# Altium schematic sheet Circuit_Schematic.SchDoc
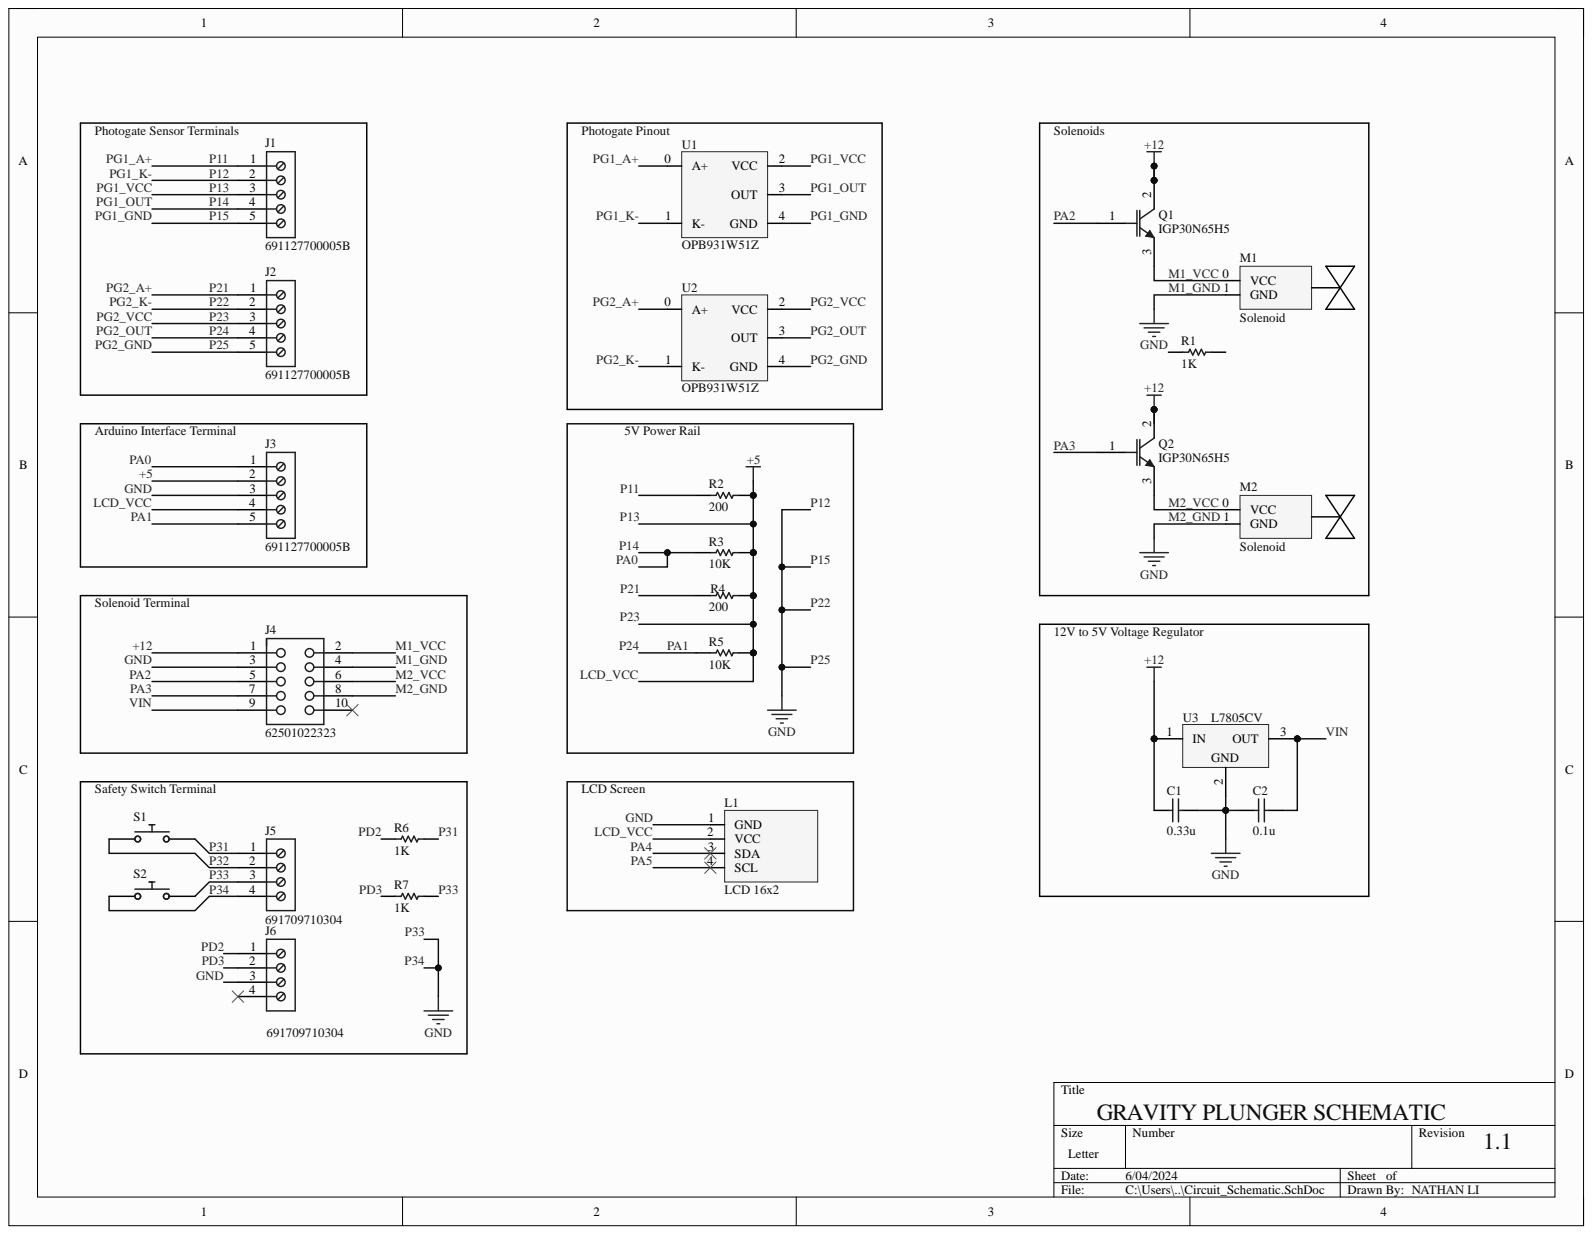
[diagram p0: Circuit_Schematic.SchDoc]

## Parts
C1  value 0.33u  (hidden comment: Capacitor)
C2  value 0.1u  (hidden comment: Capacitor)
J1  691127700005B  [691127700005B]
J2  691127700005B  [691127700005B]
J3  691127700005B  [691127700005B]
J4  62501022323  [62501022323]
J5  691709710304  [691709710304]
J6  691709710304  [691709710304]
L1  LCD 16x2
M1  Solenoid
M2  Solenoid
Q1  IGP30N65H5  [INF-PG-TO220-3-1_A_V]
Q2  IGP30N65H5  [INF-PG-TO220-3-1_A_V]
R1  value 1K  (hidden comment: Resistor)
R2  value 200  (hidden comment: Resistor)
R3  value 10K  (hidden comment: Resistor)
R4  value 200  (hidden comment: Resistor)
R5  value 10K  (hidden comment: Resistor)
R6  value 1K  (hidden comment: Resistor)
R7  value 1K  (hidden comment: Resistor)
S1  Push Button Normally Opened  (value not drawn)
S2  Push Button Normally Opened  (value not drawn)
U1  OPB931W51Z
U2  OPB931W51Z
U3  L7805CV  [TO220]

## Nets
+5: J1.3 J2.3 J3.2 J3.4 L1.2(VCC) R2.2 R3.2 R4.2 R5.2 U1.2(VCC) U2.2(VCC)
+12: C1.1 J4.1 Q1.2 Q2.2 U3.1
GND: C1.2 C2.1 J1.2 J1.5 J2.2 J2.5 J3.3 J4.3 J4.4 J4.8 J5.3 J5.4 J6.3 L1.1(GND) M1.1 M2.1 R7.2 S2.1 S2.2 U1.1(K-) U1.4(GND) U2.1(K-) U2.4(GND) U3.2
M1_VCC: J4.2 M1.0 Q1.3
M2_VCC: J4.6 M2.0 Q2.3
P11: J1.1 R2.1 U1.0(A+)
P14: J1.4 J3.1 R3.1 U1.3(OUT)
P21: J2.1 R4.1 U2.0(A+)
P24: J2.4 J3.5 R5.1 U2.3(OUT)
P31: J5.1 R6.2 S1.2
P32: J5.2 S1.1
PA2: J4.5 Q1.1
PA3: J4.7 Q2.1
PA4: L1.3(SDA)
PA5: L1.4(SCL)
PD2: J6.1 R6.1
PD3: J6.2 R7.1
VIN: C2.2 J4.9 U3.3

Not connected: J4 10; J6 4; R1 1 2

## Library data of the parts
C1 C2 = Capacitor: SimulationGenericComponent: True; Component Kind: Standard; Description: Capacitor; Library Name: Simulation Generic Components.IntLib; Simulation: C_Capacitor_Default
J1-J3 = CMP-1502-03273-2: NexarPartId: 27602165; Connector Type: Terminal Block; Current: 20A; Number of Contacts: 5; Number of Levels: 1; Pitch: 5.0038mm; Plating: Steel; Stripping Length: 9mm; Voltage: 300V; Wire Gauge (Max): 30AWG; Wire Gauge (Min): 12AWG; Color: Green; Component Kind: Standard; Contact Material: Brass; Contact Plating: Tin; Current Rating: 20A; Height: 19.5mm; Housing Material: Nylon; Lead Pitch: 5mm; Length: 18.6mm; Library Name: Altium Content Vault; Max Operating Temperature: 105°C; Min Operating Temperature: -40°C; Mount: Through Hole; Number of Pins: 5; Number of Positions Per Level: 5; Packaging: Box; REACH SVHC: Unknown; RoHS: Compliant; Termination: Screw; Voltage Rating: 300V; Width: 27.5mm; Wire/Cable Gauge: 30AWG
J4 = CMP-1502-00257-2: NexarPartId: 116664104; Current Rating: 1A; Insulation Resistance: 1GΩ; Pitch: 2mm; Component Kind: Standard; Insulation Material: PBT; Length: 14.1mm; Library Name: Altium Content Vault; Max Operating Temperature: 125°C; Min Operating Temperature: -40°C; Number of Pins: 10
J5 J6 = CMP-1502-03337-2: NexarPartId: 34171771; Connector Type: Screw; Current: 6A; Number of Contacts: 4; Number of Levels: 1; Pitch: 5mm; Plating: Steel; Voltage: 300V; Wire Gauge (Max): 30AWG; Color: Black; Component Kind: Standard; Contact Material: Nickel; Contact Plating: Tin; Current Rating: 6A; Flammability Rating: UL94 V-0; Height: 10.2mm; Housing Material: Nylon; Lead Pitch: 5mm; Length: 20mm; Library Name: Altium Content Vault; Max Operating Temperature: 130°C; Min Operating Temperature: -40°C; Mount: Surface Mount; Number of Pins: 4; Number of Positions: 4; Number of Positions Per Level: 4; Number of Rows: 1; Packaging: Digi-Reel®; REACH SVHC: Unknown; RoHS: Compliant; Termination: Screw; Voltage Rating: 300V; Wire Gauge (Min): 30AWG; Wire/Cable Gauge: 30AWG
L1 = LCD 16x2: Liquid I2C LCD 16x2 Display; Component Kind: Standard; Library Name: SchemLib.SchLib
Q1 Q2 = CMP-1168-00025-1: High Speed 5 FAST IGBT in TRENCHSTOP(TM) 5 Technology, 650 V VCE, -40 to 175 degC, PG-TO220-3, Tube, Green; Manufacturer: Infineon; Eoff (typ): 0.1 mJ; Eon (typ): 0.28Â mJ; IC(max) @ 25degrees: 55 A; IC(max) @ 100degrees: 35 A; ICpuls (max): 90 A; P tot (max): 188 W; QGate (typ): 70 nC; td(off) (typ): 177 ns; td(on) (typ): 19 ns; tf (typ): 14 ns; tr (typ): 9 ns; VCE (max): 650 V; VCE(sat) (typ): 1.65Â V; Component Kind: Standard; ComponentLink1Description: Manufacturer URL; ComponentLink1URL: http://www.infineon.com/; ComponentLink2Description: Datasheet; ComponentLink2URL: http://www.infineon.com/dgdl/IGP30N65H5_2_1.pdf?folderId=db3a3043156fd5730115f56849b61941&fileId=5546d461464245d30146a4dca9be6ccd; ComponentLink3Description: Package Specification; ComponentLink3URL: http://www.infineon.com/dgdl/IKP30N65H5_2_1.pdf?folderId=db3a3043156fd5730115f56849b61941&fileId=5546d461464245d30146a51a2ca36d28; DatasheetVersion: Rev. 2.1; Halogen-free: TRUE; Library Name: Altium Content Vault; Mounting Technology: Through Hole; PackageDescription: 3-Pin Plastic (Green) Transistor Single Outline Package, 10.03 x 8.98 mm Body, 2.54 mm Pitch; PackageReference: PG-TO220-3-1_A; PackageVersion: Rev. 05, 08/2007; Packing: Tube; PartNumber: IGP30N65H5
R1-R7 = Resistor: SimulationGenericComponent: True; TC1: 0; Component Kind: Standard; Description: Resistor; Library Name: Simulation Generic Components.IntLib; Simulation: R_Resistor_Default; TC2: 0
S1 S2 = Push Button NO: closed: 0; Rclosed: 1m; Ropen: 1Meg; SimulationGenericComponent: True; Component Kind: Standard; Description: Push Button Normally Opened; Library Name: Simulation Generic Components.IntLib; Simulation: PUSH_BUTTON_NO
U1 U2 = OPB931W51Z: Photogate Interrupter; Component Kind: Standard; ComponentLink1Description: Datasheet; ComponentLink1URL: https://www.ttelectronics.com/TTElectronics/media/ProductFiles/Datasheet/OPB930-940-L-W.pdf; Library Name: SchemLib.SchLib; Supplier 1: Newark; Supplier Part Number 1: 73K1183
U3 = CMP-0244-00483-1: Positive Voltage Regulator, 5V, 3-Pin TO-220; Component Kind: Standard; ComponentLink1Description: Manufacturer URL; ComponentLink1URL: http://www.st.com; ComponentLink2Description: Datasheet; ComponentLink2URL: http://www.st.com/internet/com/TECHNICAL_RESOURCES/TECHNICAL_LITERATURE/DATASHEET/CD00000444.pdf; ComponentLink3Description: Package Specification; ComponentLink3URL: http://www.st.com/internet/com/TECHNICAL_RESOURCES/TECHNICAL_LITERATURE/PACKAGE_INFORMATION/CD00004649.pdf; DatasheetVersion: 16/11/2011; Library Name: Altium Content Vault; PackageDescription: TO, Thru-Hole, Vertical, Heatsink Mounted; 3 In-Line Leads; Pitch 2.54 mm; PackageReference: TO220; PackageVersion: ver3.0
M1 M2 = Solenoid: Component Kind: Standard; Library Name: SchemLib.SchLib
